# Altium schematic sheet Sheet3.SchDoc(Sheet3)
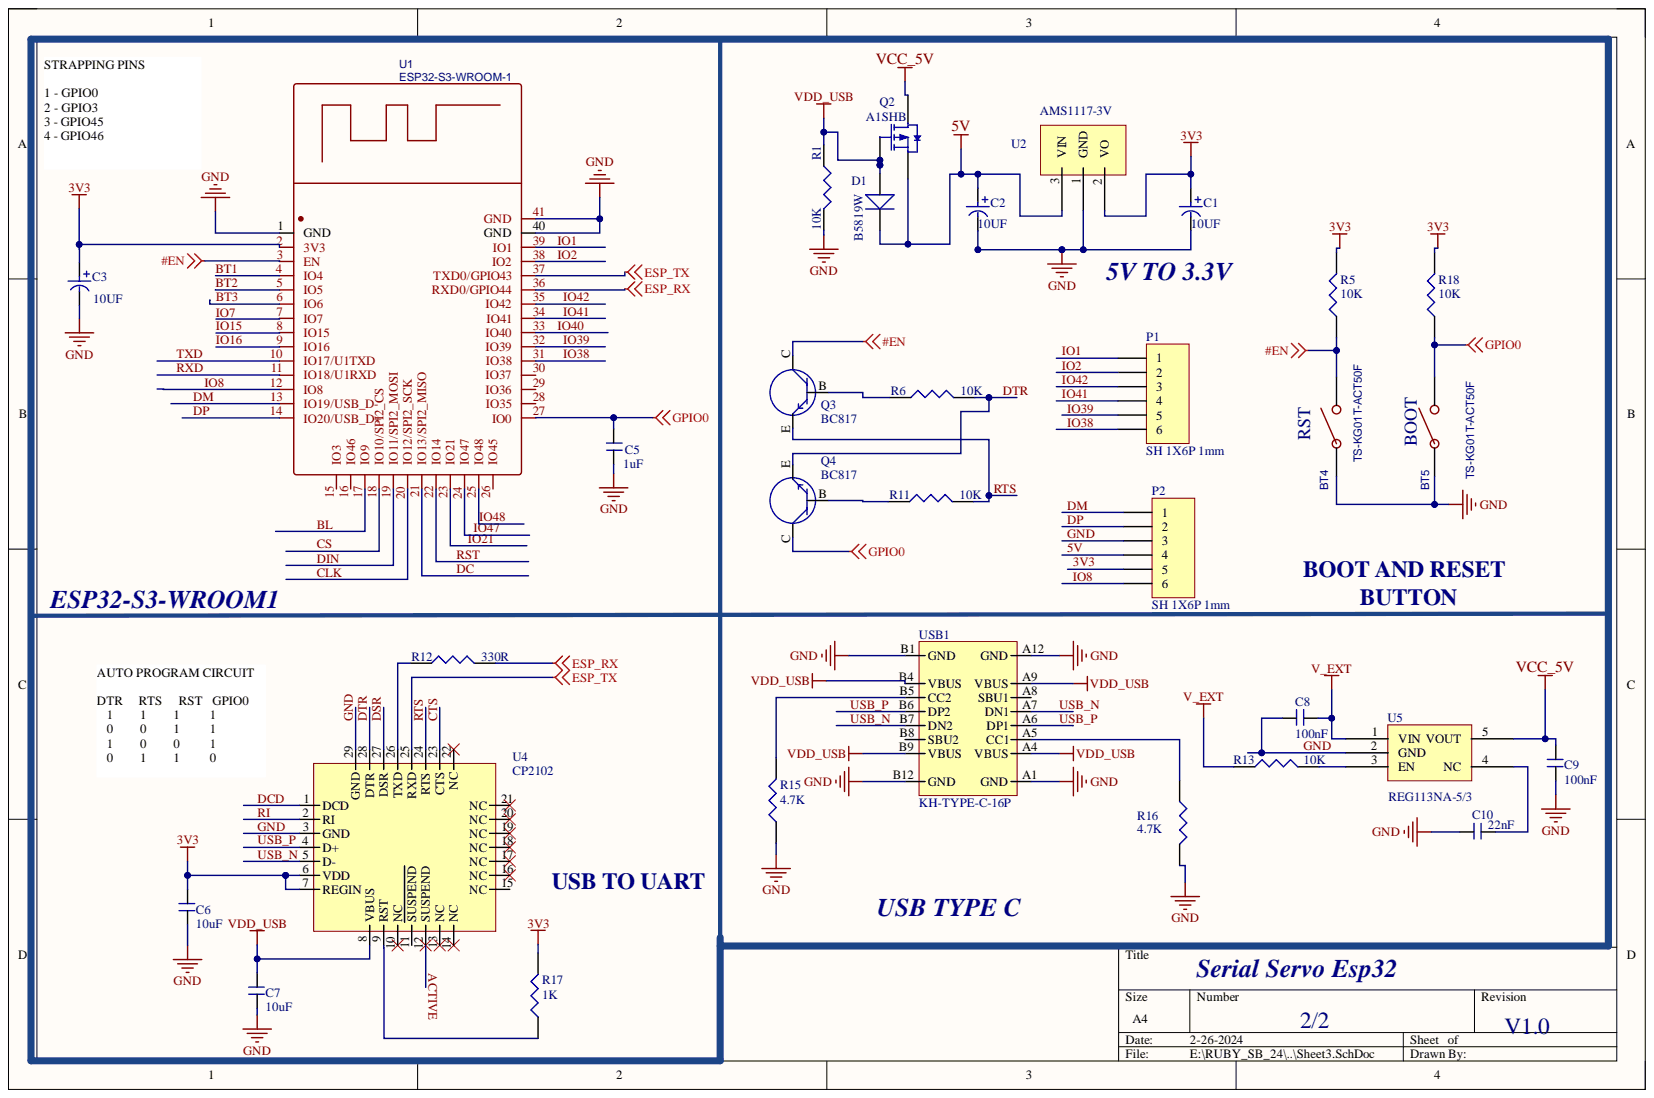
[diagram p0: Sheet3.SchDoc]

## Parts
BT4  TS-KG01T-ACT50F  [SW_SMD_L3.9_W2.9 P4.15]
BT5  TS-KG01T-ACT50F  [SW_SMD_L3.9_W2.9 P4.15]
C1  10UF  [Tantlum_A_3216]
C2  10UF  [Tantlum_A_3216]
C3  10UF  [C_0603]
C5  1uF  [C_0603]
C6  10uF  [C_0603]
C7  10uF  [C_0603]
C8  100nF  [C_0603]
C9  100nF  [C_0603]
C10  22nF  [C_0603]
D1  B5819W  [SOD-123]
P1  SH 1X6P 1mm  [SH 1X6P 1MM]
P2  SH 1X6P 1mm  [SH 1X6P 1MM]
Q2  A1SHB  [BSS84]
Q3  BC817  [SOT23]
Q4  BC817  [SOT23]
R1  10K  [R_0603]
R5  10K  [R_0603]
R6  10K  [R_0603]
R11  10K  [R_0603]
R12  330R  [R_0603]
R13  10K  [R_0603]
R15  4.7K  [R_0603]
R16  4.7K  [R_0603]
R17  1K  [R_0603]
R18  10K  [R_0603]
U1  ESP32-S3-WROOM-1  [ESP32-S3-WROOM1]
U2  AMS1117-3V  [AMS1117_3V3]
U4  CP2102  [CP2102]
U5  REG113NA-5/3  [SOT23-5]
USB1  KH-TYPE-C-16P  [USB TYPE _C]

## Nets
#EN: BT4.2 Q3.C R5.1 U1.3(EN)
ESP_RX: R12.2 U1.36(RXD0/GPIO44)
ESP_TX: U1.37(TXD0/GPIO43) U4.25(RXD)
GPIO0: BT5.2 C5.2 Q4.C R18.1 U1.27(IO0)
V_EXT: C8.1 R13.1 U5.1(VIN)
VCC_5V: C9.1 Q2.3 U5.5(VOUT)
VDD_USB: C7.1 D1.A Q2.1 R1.1 U4.8(VBUS) USB1.A4(VBUS) USB1.A9(VBUS) USB1.B4(VBUS) USB1.B9(VBUS)
3V3: C1.1 C3.1 C6.1 P2.5 R5.2 R17.2 R18.2 U1.2(3V3) U2.2 U4.6(VDD) U4.7(REGIN)
5V: C2.1 D1.K P2.4 Q2.2 U2.3
ACTIVE: U4.12(SUSPEND)
BL: U1.17(IO9)
BT1: U1.4(IO4)
BT2: U1.5(IO5)
BT3: U1.6(IO6)
CLK: U1.20(IO12/SPI2_SCK)
CS: U1.18(IO10/SPI2_CS)
CTS: U4.23(CTS)
DC: U1.21(IO13/SPI2_MISO)
DCD: U4.1(DCD)
DIN: U1.19(IO11/SPI2_MOSI)
DM: P2.1 U1.13(IO19/USB_D-)
DP: P2.2 U1.14(IO20/USB_D+)
DSR: U4.27(DSR)
DTR: Q4.E R6.2 U4.28(DTR)
GND: BT4.1 BT5.1 C1.2 C2.2 C3.2 C5.1 C6.2 C7.2 C8.2 C9.2 C10.2 P2.3 R1.2 R15.1 R16.1 U1.1(GND) U1.40(GND) U1.41(GND) U2.1 U4.3(GND) U4.29(GND) U5.2(GND) USB1.A1(GND) USB1.A12(GND) USB1.B1(GND) USB1.B12(GND)
IO1: P1.1 U1.39(IO1)
IO2: P1.2 U1.38(IO2)
IO7: U1.7(IO7)
IO8: P2.6 U1.12(IO8)
IO15: U1.8(IO15)
IO16: U1.9(IO16)
IO21: U1.23(IO21)
IO38: P1.6 U1.31(IO38)
IO39: P1.5 U1.32(IO39)
IO40: U1.33(IO40)
IO41: P1.4 U1.34(IO41)
IO42: P1.3 U1.35(IO42)
IO47: U1.24(IO47)
IO48: U1.25(IO48)
RI: U4.2(RI)
RST: U1.22(IO14)
RTS: Q3.E R11.2 U4.24(RTS)
RXD: U1.11(IO18/U1RXD)
TXD: U1.10(IO17/U1TXD)
USB_N: U4.5(D-) USB1.A7(DN1) USB1.B7(DN2)
USB_P: U4.4(D+) USB1.A6(DP1) USB1.B6(DP2)
NetC10_1: C10.1 U5.4(NC)
NetQ3_B: Q3.B R6.1
NetQ4_B: Q4.B R11.1
NetR12_1: R12.1 U4.26(TXD)
NetR13_2: R13.2 U5.3(EN)
NetR15_2: R15.2 USB1.B5(CC2)
NetR16_2: R16.2 USB1.A5(CC1)
NetR17_1: R17.1 U4.9(RST)

Not connected: U1 15(IO3) 16(IO46) 26(IO45) 28(IO35) 29(IO36) 30(IO37); U4 10(NC) 11(SUSPEND) 13(NC) 14(NC) 15(NC) 16(NC) 17(NC) 18(NC) 19(NC) 20(NC) 21(NC) 22(NC); USB1 A8(SBU1) B8(SBU2)

## Library data of the parts
BT4 BT5 = JT1185SAEM: BOM_Manufacturer: NAMAE(韩国南涯); Contributor: LCSC; BOM_JLCPCB Part Class: Extended Part; BOM_Manufacturer Part: JT1185SAEM; BOM_Supplier: LCSC; BOM_Supplier Part: C465068; Component Kind: Standard; spicePre: S; spiceSymbolName: JT1185SAEM
C1-C3 = CAP_ELE: Component Kind: Standard; Library Name: MIX.SchLib
C5-C10 = CAP_NONPOLAR: Component Kind: Standard; Library Name: dxp_pasl_lib.IntLib
D1 = DIODE: Component Kind: Standard; Library Name: dxp_pasl_lib.IntLib
P1 P2 = Header 6: Header, 6-Pin; Component Kind: Standard; LatestRevisionDate: 17-Jul-2002; LatestRevisionNote: Re-released for DXP Platform.; Library Name: Miscellaneous Connectors.IntLib; Signal Integrity: Connector; Publisher: Altium Limited
Q2 = BSS84: 50V 130mA 250mW 10Ω 10V,130mA 2V 1mA P Channel SOT-23(SOT-23-3) MOSFETs ROHS #C493579; PackageDocument: 1995/1996; CATEGORY: MOSFET; Component Kind: Standard; LatestRevisionDate: 17-Jul-2002; LatestRevisionNote: Re-released for DXP Platform.; PackageReference: E3; Published: 8-Jun-2000; Library Name: dxp_pasl_lib.IntLib; Publisher: Altium Limited
Q3 Q4 = TRANSISTER_NPN: Component Kind: Standard; Library Name: dxp_pasl_lib.IntLib
R1 R5 R6 R11-R13 R15-R18 = RES: Component Kind: Standard; Library Name: dxp_pasl_lib.IntLib
U1 = ESP32-S3-WROOM-1(N16): BOM_Manufacturer: ESPRESSIF(乐鑫); BOM_Manufacturer Part: ESP32-S3-WROOM-1-N16; Contributor: lcsc; BOM_JLCPCB Part Class: Extended Part; BOM_Supplier: LCSC; BOM_Supplier Part: C2913199; Component Kind: Standard; link: https://atta.szlcsc.com/upload/public/pdf/source/20220628/A2AC12B3C87735CAEB7EBE2DBE80936C.pdf; spicePre: U; spiceSymbolName: ESP32-S3-WROOM-1(N16)
U2 = AMS1117: Component Kind: Standard; Library Name: dxp_pasl_lib.IntLib
U4 = CP2102_1: QFN, 28-Leads, Body 5.0x5.0mm (max), Pitch 0.50mm, IPC Medium Density; Component Kind: Standard; Library Name: dxp_pasl_lib.IntLib
U5 = AP2112: 600mA CMOS LDO REGULATOR WITH ENABLE SOT-23-5 PACKAGE; Component Kind: Standard; Library Name: dxp_pasl_lib.IntLib
USB1 = USB TYPE-C KH-TYPE-C-16P: 3A 1 Surface Mount 16 Female -40?~+85? Type-C SMD USB Connectors ROHS #  C709357; Component Kind: Standard; ComponentLink2Description: New link; ComponentLink2URL: https://lcsc.com/product-detail/USB-Connectors_Shenzhen-Kinghelm-Elec-KH-TYPE-C-16P_C709357.html; Supplier 1: LCSC; Supplier Part Number 1: C165948; Library Name: dxp_pasl_lib.IntLib
